# Altium schematic sheet 03_Catalina.SchDoc
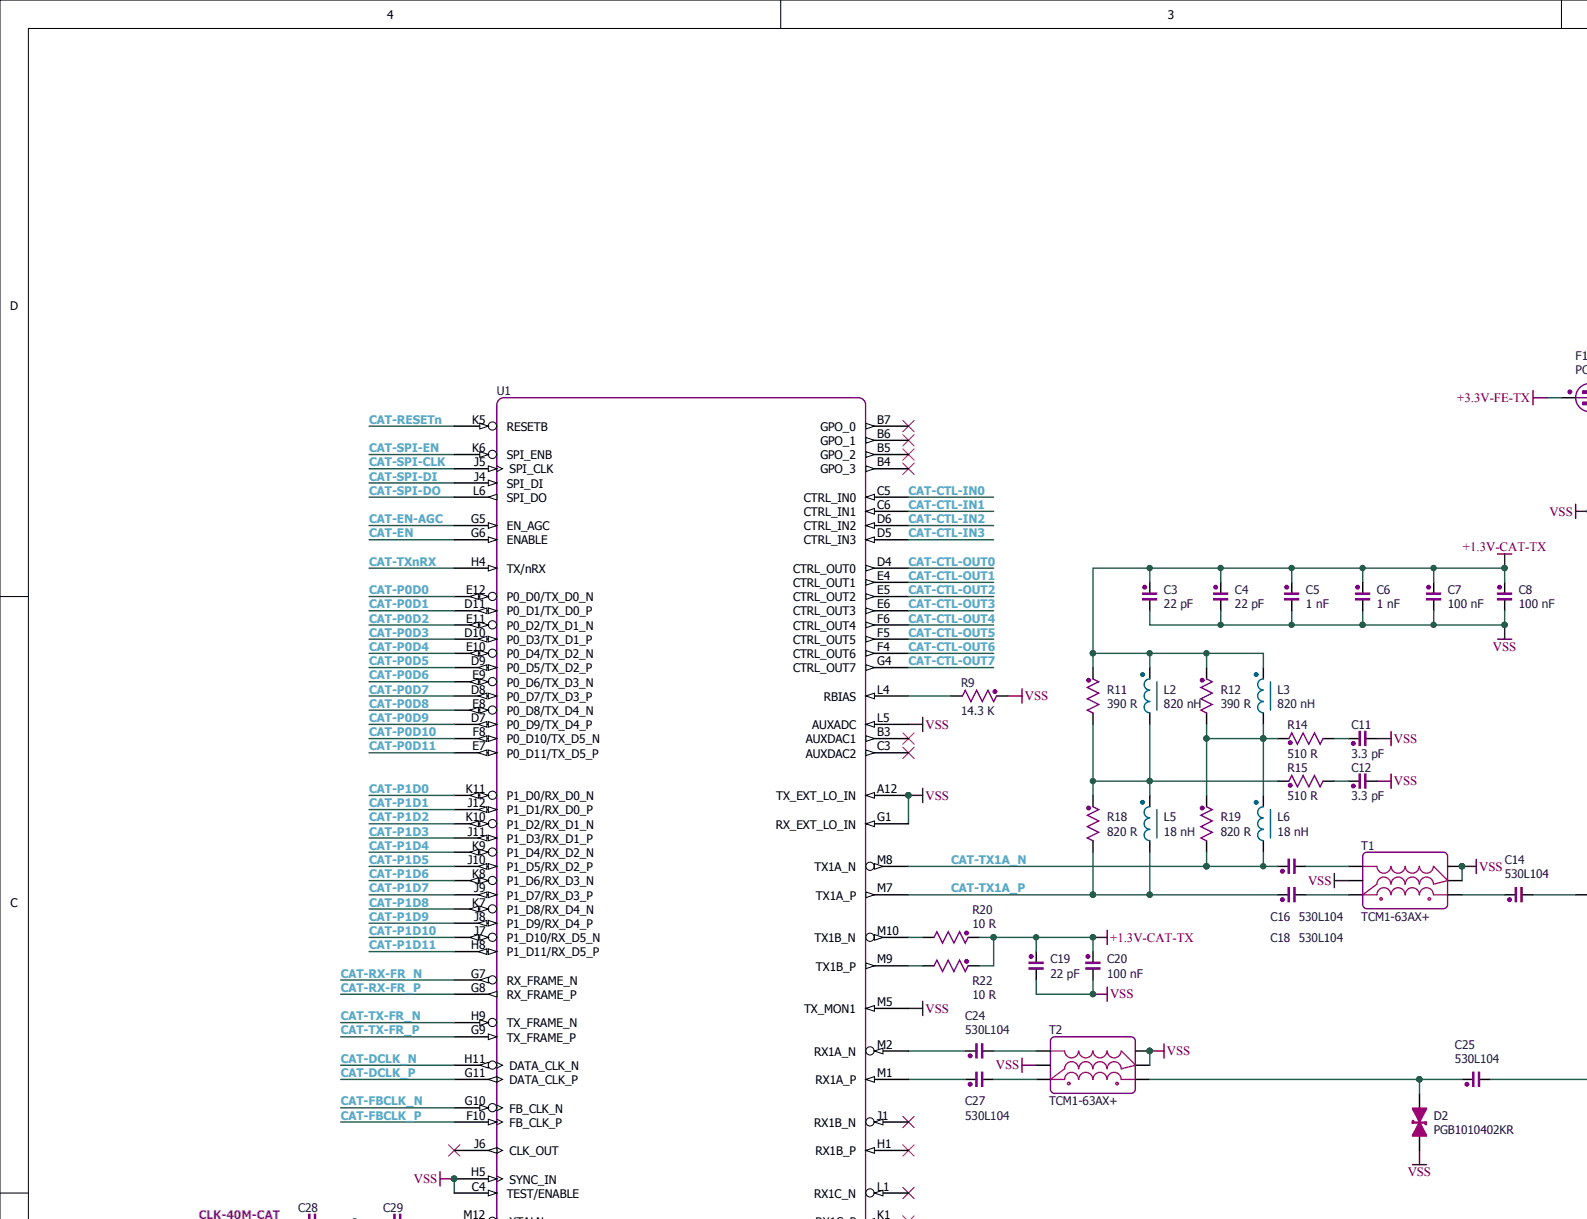
[diagram p0: 03_Catalina.SchDoc, top left]
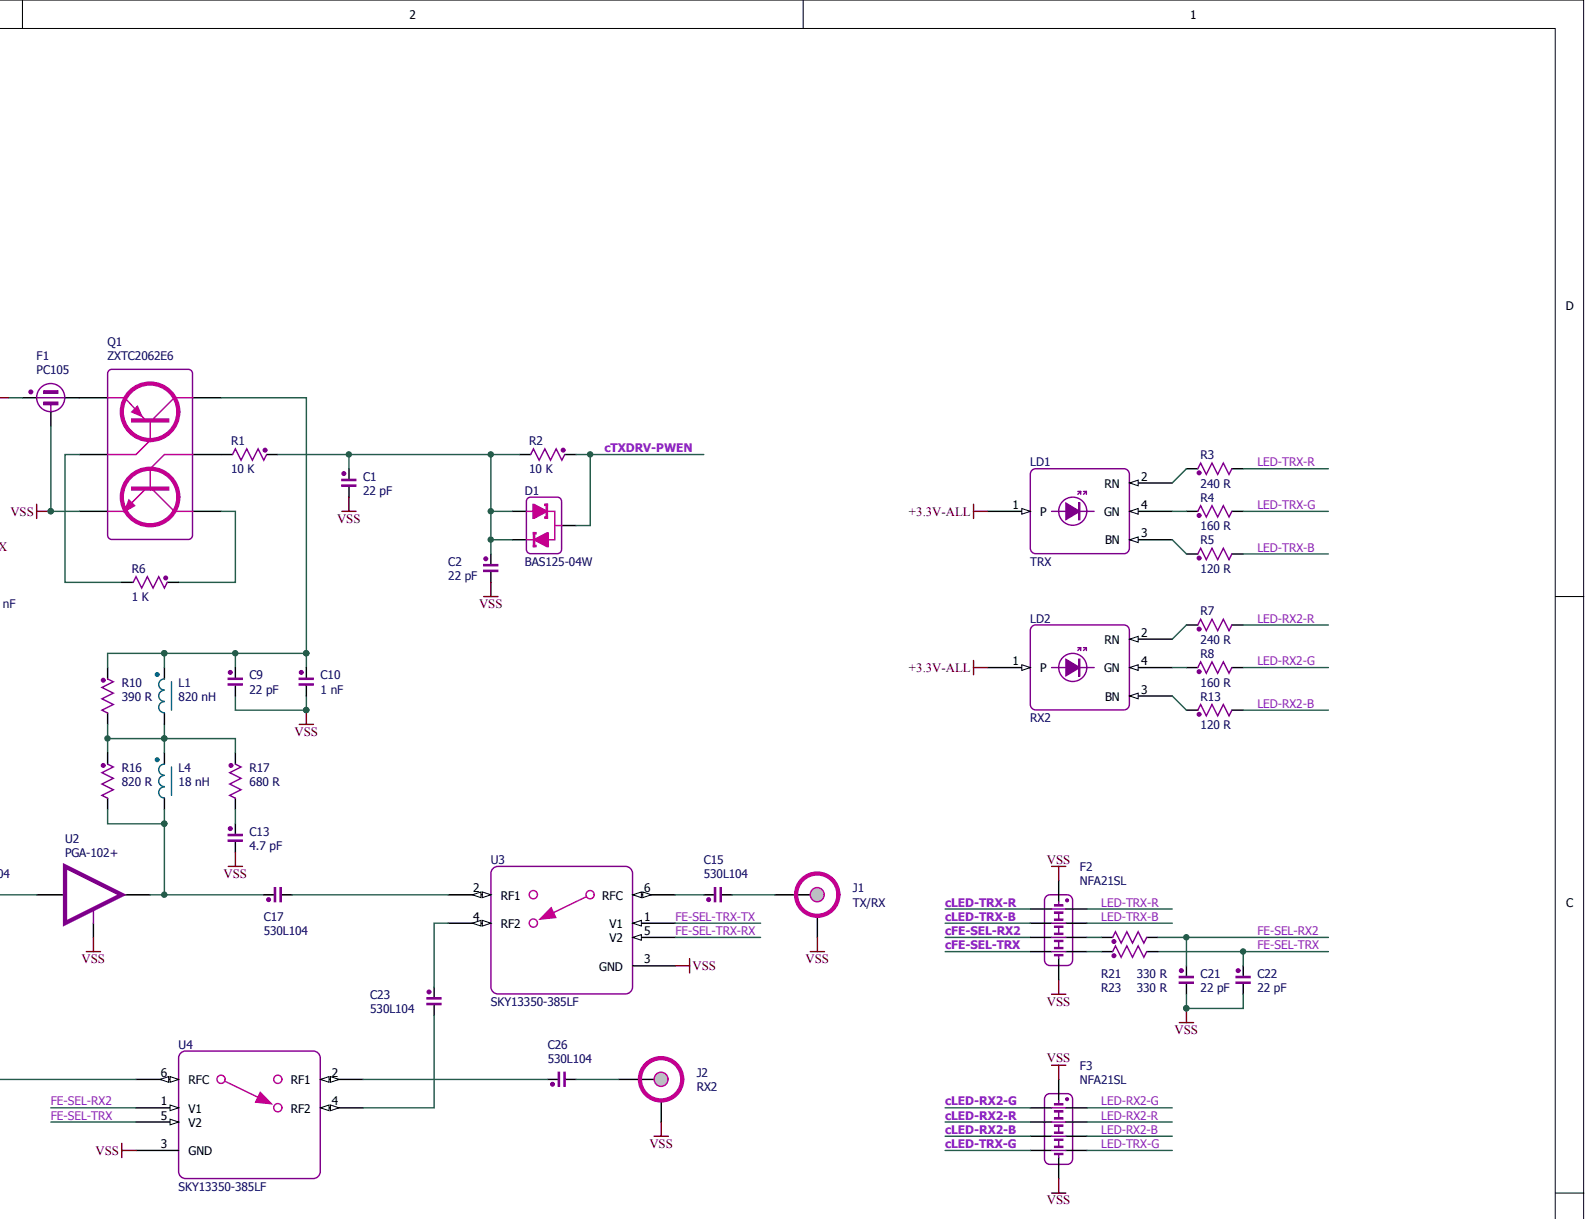
[diagram p1: 03_Catalina.SchDoc, top right]
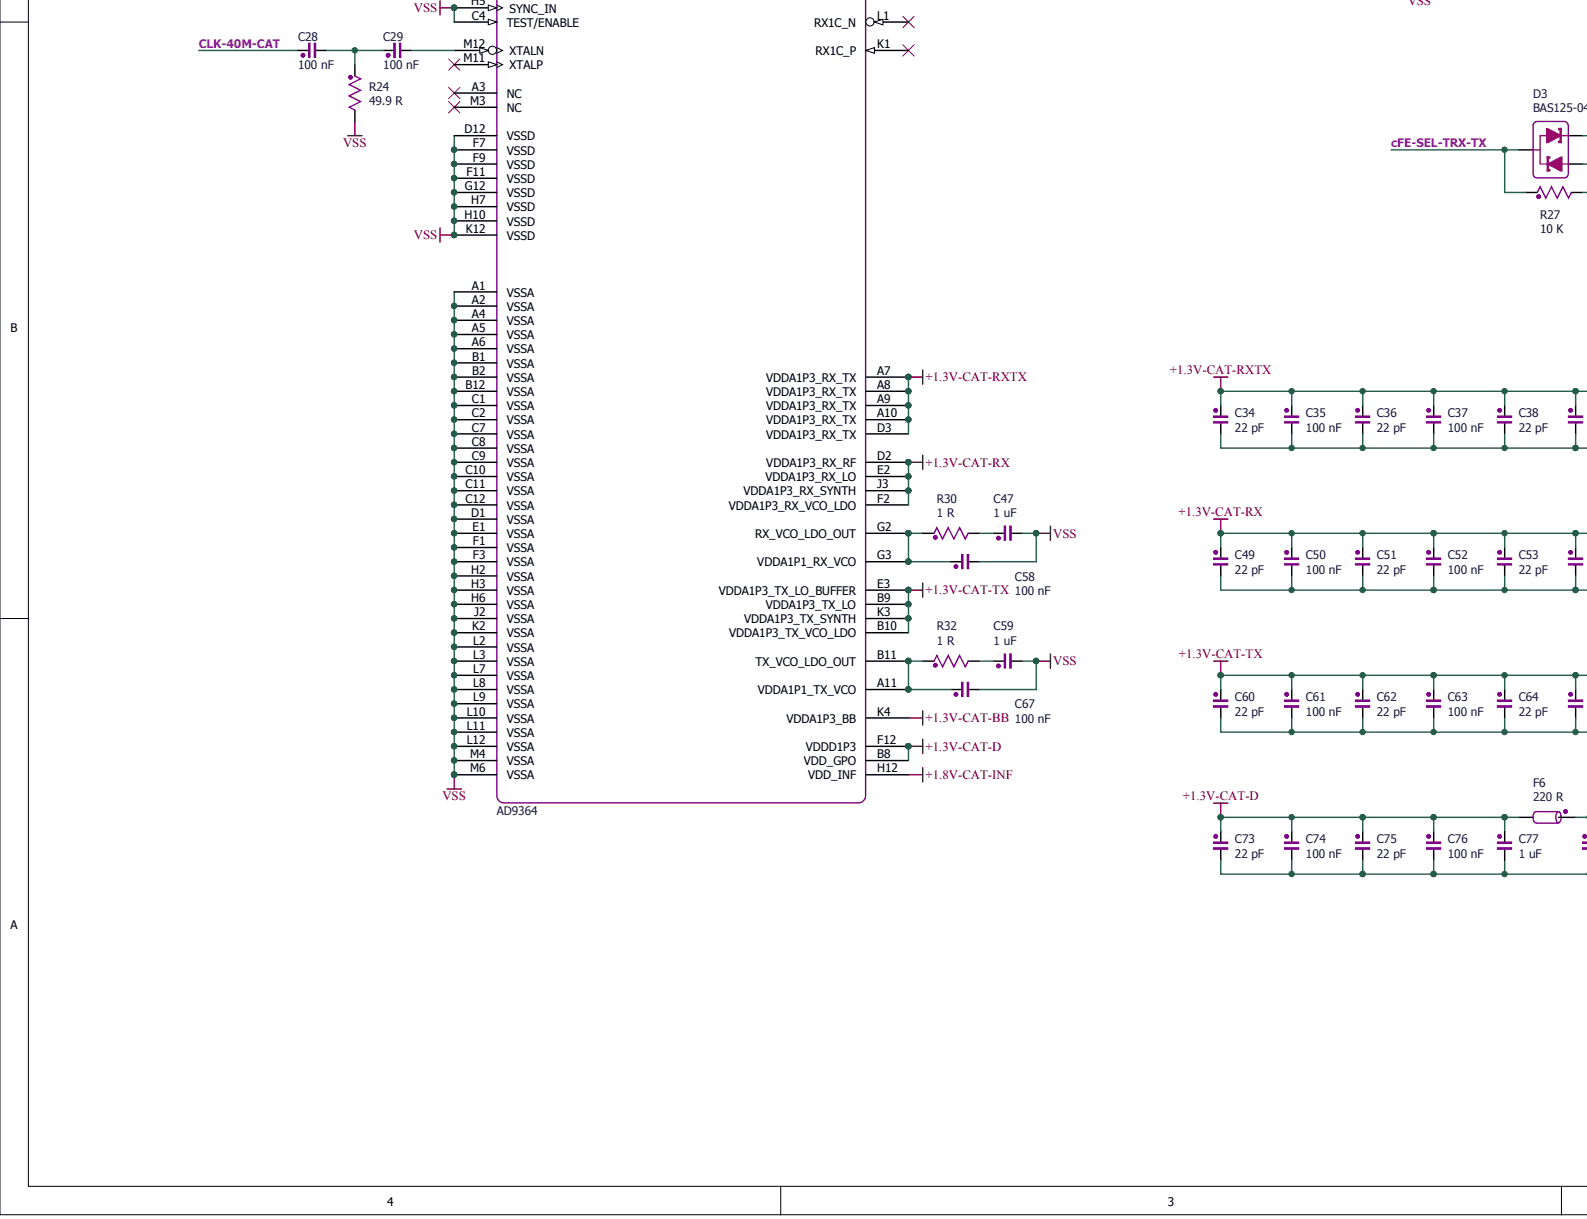
[diagram p2: 03_Catalina.SchDoc, bottom left]
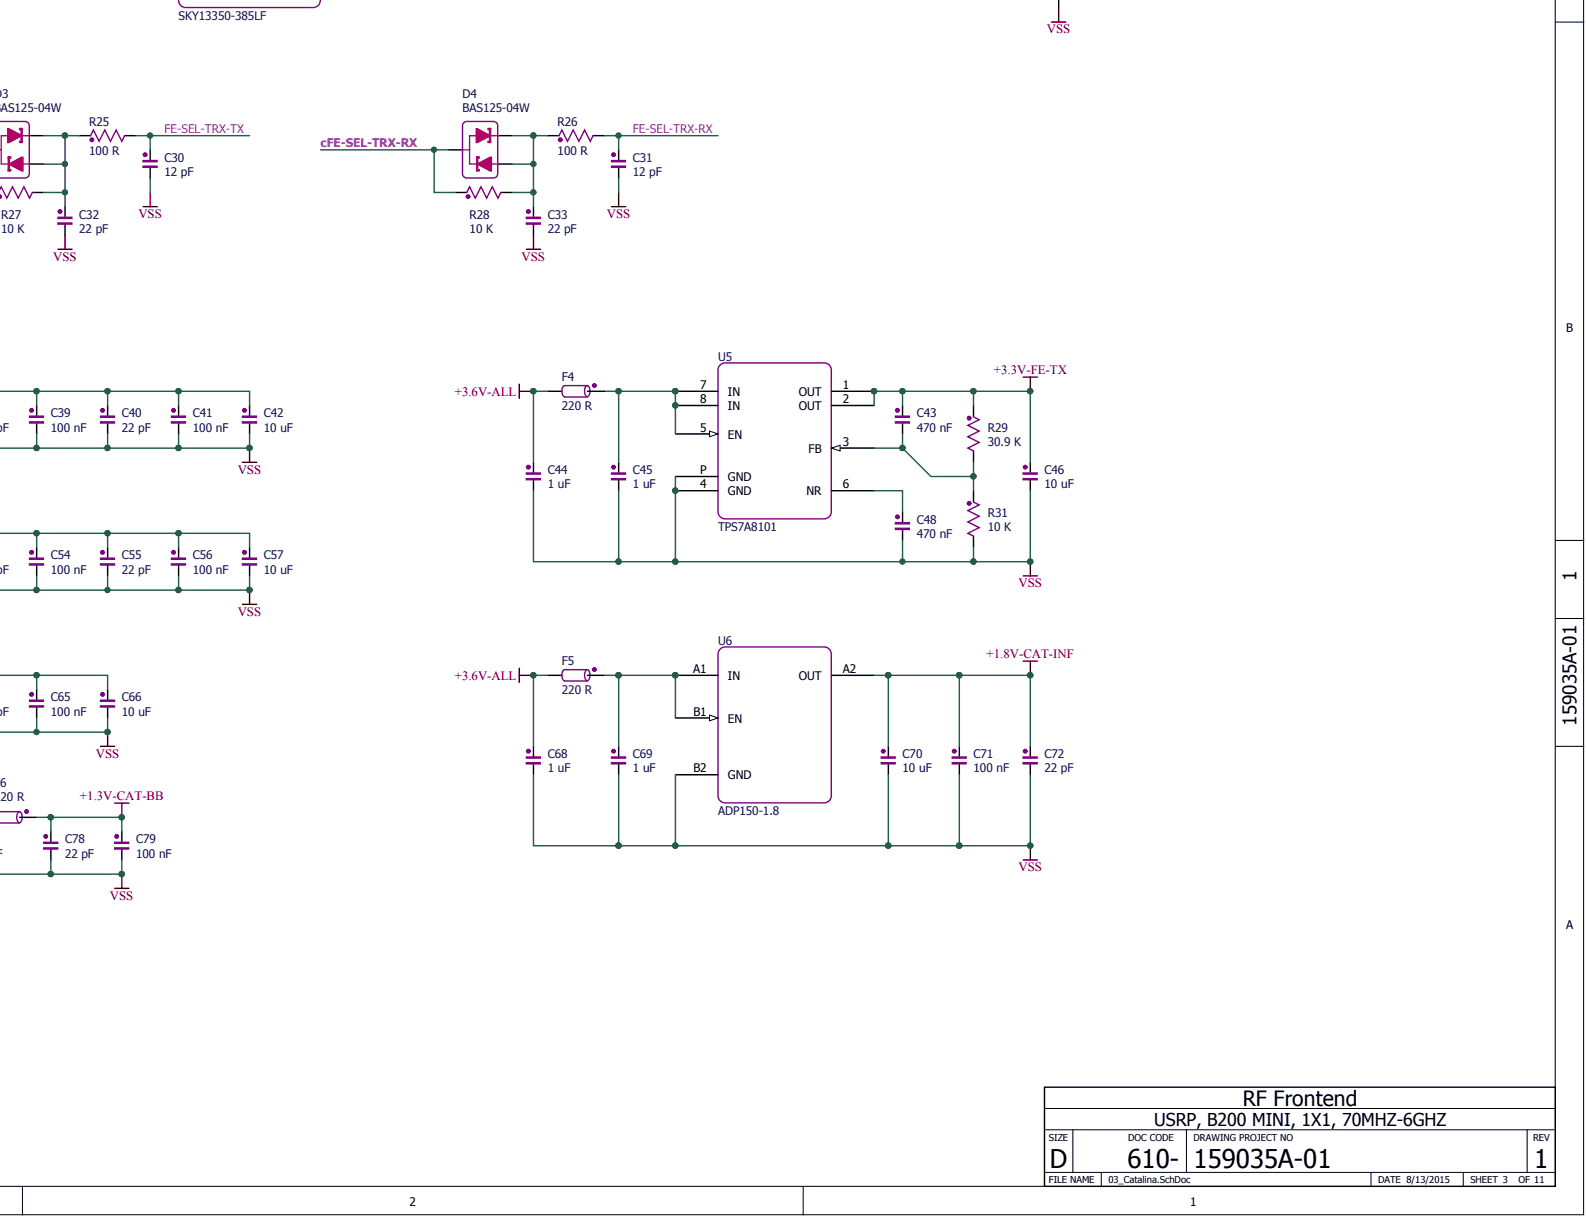
[diagram p3: 03_Catalina.SchDoc, bottom right]

## Parts
C1  22 pF  [0402-CAP-RF]
C2  22 pF  [0402-CAP-RF]
C3  22 pF  [0201-CAP-RF]
C4  22 pF  [0201-CAP-RF]
C5  1 nF  [0201-CAP]
C6  1 nF  [0201-CAP]
C7  100 nF  [0201-CAP]
C8  100 nF  [0201-CAP]
C9  22 pF  [0402-CAP-rf]
C10  1 nF  [0402-CAP]
C11  3.3 pF  [0201-CAP-RF]
C12  3.3 pF  [0201-CAP-RF]
C13  4.7 pF  [0201-CAP-RF]
C14  530L104  [0402-CAP-RF]
C15  530L104  [0402-CAP-RF]
C16  530L104  [0402-CAP-RF]
C17  530L104  [0402-CAP-RF]
C18  530L104  [0402-CAP-RF]
C19  22 pF  [0201-CAP-RF]
C20  100 nF  [0201-CAP]
C21  22 pF  [0201-CAP-RF]
C22  22 pF  [0201-CAP-RF]
C23  530L104  [0402-CAP-rf]
C24  530L104  [0402-CAP-RF]
C25  530L104  [0402-CAP-rf]
C26  530L104  [0402-CAP-RF]
C27  530L104  [0402-CAP-RF]
C28  100 nF  [0201-CAP]
C29  100 nF  [0201-CAP]
C30  12 pF  [0201-CAP-RF]
C31  12 pF  [0201-CAP-RF]
C32  22 pF  [0402-CAP-RF]
C33  22 pF  [0402-CAP-RF]
C34  22 pF  [0201-CAP-RF]
C35  100 nF  [0201-CAP]
C36  22 pF  [0201-CAP-RF]
C37  100 nF  [0201-CAP]
C38  22 pF  [0201-CAP-RF]
C39  100 nF  [0201-CAP]
C40  22 pF  [0201-CAP-RF]
C41  100 nF  [0201-CAP]
C42  10 uF  [0402-CAP]
C43  470 nF  [0201-CAP]
C44  1 uF  [0402-CAP]
C45  1 uF  [0402-CAP]
C46  10 uF  [0402-CAP]
C47  1 uF  [0402-CAP]
C48  470 nF  [0201-CAP]
C49  22 pF  [0201-CAP-RF]
C50  100 nF  [0201-CAP]
C51  22 pF  [0201-CAP-RF]
C52  100 nF  [0201-CAP]
C53  22 pF  [0201-CAP-RF]
C54  100 nF  [0201-CAP]
C55  22 pF  [0201-CAP-RF]
C56  100 nF  [0201-CAP]
C57  10 uF  [0402-CAP]
C58  100 nF  [0201-CAP]
C59  1 uF  [0402-CAP]
C60  22 pF  [0201-CAP-RF]
C61  100 nF  [0201-CAP]
C62  22 pF  [0201-CAP-RF]
C63  100 nF  [0201-CAP]
C64  22 pF  [0201-CAP-RF]
C65  100 nF  [0201-CAP]
C66  10 uF  [0402-CAP]
C67  100 nF  [0201-CAP]
C68  1 uF  [0402-CAP]
C69  1 uF  [0402-CAP]
C70  10 uF  [0402-CAP]
C71  100 nF  [0201-CAP]
C72  22 pF  [0201-CAP-RF]
C73  22 pF  [0201-CAP-RF]
C74  100 nF  [0201-CAP]
C75  22 pF  [0201-CAP-RF]
C76  100 nF  [0201-CAP]
C77  1 uF  [0402-CAP]
C78  22 pF  [0201-CAP-RF]
C79  100 nF  [0201-CAP]
D1  BAS125-04W  [SOT-323]
D2  PGB1010402KR  [0402-TVS]
D3  BAS125-04W  [SOT-323]
D4  BAS125-04W  [SOT-323]
F1  PC105  [0805-NF]
F2  NFA21SL  [0402-NF-4]
F3  NFA21SL  [0402-NF-4]
F4  220 R  [0402-BEAD]
F5  220 R  [0402-BEAD]
F6  220 R  [0402-BEAD]
J1  TX/RX  [142-0771-821]
J2  RX2  [142-0771-821]
L1  820 nH  [0603LS]
L2  820 nH  [0603LS]
L3  820 nH  [0603LS]
L4  18 nH  [0403HQ]
L5  18 nH  [0403HQ]
L6  18 nH  [0403HQ]
LD1  TRX  [SMLP34]
LD2  RX2  [SMLP34]
Q1  ZXTC2062E6  [SOT-26]
R1  10 K  [0402-RES]
R2  10 K  [0402-RES]
R3  240 R  [0201-RES]
R4  160 R  [0201-RES]
R5  120 R  [0201-RES]
R6  1 K  [0402-RES]
R7  240 R  [0201-RES]
R8  160 R  [0201-RES]
R9  14.3 K  [0402-RES-H]
R10  390 R  [0402-RES-H]
R11  390 R  [0402-RES-H]
R12  390 R  [0402-RES-H]
R13  120 R  [0201-RES]
R14  510 R  [0201-RES]
R15  510 R  [0201-RES]
R16  820 R  [0201-RES]
R17  680 R  [0201-RES]
R18  820 R  [0201-RES]
R19  820 R  [0201-RES]
R20  10 R  [0201-RES]
R21  330 R  [0201-RES]
R22  10 R  [0201-RES]
R23  330 R  [0201-RES]
R24  49.9 R  [0201-RES]
R25  100 R  [0201-RES]
R26  100 R  [0201-RES]
R27  10 K  [0402-RES]
R28  10 K  [0402-RES]
R29  30.9 K  [0201-RES]
R30  1 R  [0201-RES]
R31  10 K  [0201-RES]
R32  1 R  [0201-RES]
T1  TCM1-63AX+  [DB1627]
T2  TCM1-63AX+  [DB1627]
U1  AD9364  [BC-144-7-AD9364]
U2  PGA-102+  [SOT-89]
U3  SKY13350-385LF  [MLPD-6]
U4  SKY13350-385LF  [MLPD-6]
U5  TPS7A8101  [TI-SON-8]
U6  ADP150-1.8  [ADI-WLCSP-4]

## Nets
+1.3V-CAT-BB: C78.2 C79.2 F6.2 U1.K4(VDDA1P3_BB)
+1.3V-CAT-D: C73.2 C74.2 C75.2 C76.2 C77.2 F6.1 U1.B8(VDD_GPO) U1.F12(VDDD1P3)
+1.3V-CAT-RX: C49.2 C50.2 C51.2 C52.2 C53.2 C54.2 C55.2 C56.2 C57.2 U1.D2(VDDA1P3_RX_RF) U1.E2(VDDA1P3_RX_LO) U1.F2(VDDA1P3_RX_VCO_LDO) U1.J3(VDDA1P3_RX_SYNTH)
+1.3V-CAT-RXTX: C34.2 C35.2 C36.2 C37.2 C38.2 C39.2 C40.2 C41.2 C42.2 U1.A7(VDDA1P3_RX_TX) U1.A8(VDDA1P3_RX_TX) U1.A9(VDDA1P3_RX_TX) U1.A10(VDDA1P3_RX_TX) U1.D3(VDDA1P3_RX_TX)
+1.3V-CAT-TX: C3.2 C4.2 C5.2 C6.2 C7.2 C8.2 C19.2 C20.2 C60.2 C61.2 C62.2 C63.2 C64.2 C65.2 C66.2 L2.2 L3.2 R11.2 R12.2 R20.2 R22.2 U1.B9(VDDA1P3_TX_LO) U1.B10(VDDA1P3_TX_VCO_LDO) U1.E3(VDDA1P3_TX_LO_BUFFER) U1.K3(VDDA1P3_TX_SYNTH)
+1.8V-CAT-INF: C70.2 C71.2 C72.2 U1.H12(VDD_INF) U6.A2(OUT)
+3.3V-ALL: LD1.1(P) LD2.1(P)
+3.3V-FE-TX: C43.2 C46.2 F1.2 R29.2 U5.1(OUT) U5.2(OUT)
+3.6V-ALL: C44.2 C68.2 F4.1 F5.1
VSS: C1.1 C2.1 C3.1 C4.1 C5.1 C6.1 C7.1 C8.1 C9.1 C10.1 C11.1 C12.1 C13.1 C19.1 C20.1 C21.1 C22.1 C30.1 C31.1 C32.1 C33.1 C34.1 C35.1 C36.1 C37.1 C38.1 C39.1 C40.1 C41.1 C42.1 C44.1 C45.1 C46.1 C47.1 C48.1 C49.1 C50.1 C51.1 C52.1 C53.1 C54.1 C55.1 C56.1 C57.1 C58.1 C59.1 C60.1 C61.1 C62.1 C63.1 C64.1 C65.1 C66.1 C67.1 C68.1 C69.1 C70.1 C71.1 C72.1 C73.1 C74.1 C75.1 C76.1 C77.1 C78.1 C79.1 D2.2 F1.3 F2.9 F2.10 F3.9 F3.10 J1.2 J2.2 Q1.6 R9.2 R24.1 R31.1 T1.2 T1.3 T1.5 T2.2 T2.3 T2.5 U1.A1(VSSA) U1.A2(VSSA) U1.A4(VSSA) U1.A5(VSSA) U1.A6(VSSA) U1.A12(TX_EXT_LO_IN) U1.B1(VSSA) U1.B2(VSSA) U1.B12(VSSA) U1.C1(VSSA) U1.C2(VSSA) U1.C4(TEST/ENABLE) U1.C7(VSSA) U1.C8(VSSA) U1.C9(VSSA) U1.C10(VSSA) U1.C11(VSSA) U1.C12(VSSA) U1.D1(VSSA) U1.D12(VSSD) U1.E1(VSSA) U1.F1(VSSA) U1.F3(VSSA) U1.F7(VSSD) U1.F9(VSSD) U1.F11(VSSD) U1.G1(RX_EXT_LO_IN) U1.G12(VSSD) U1.H2(VSSA) U1.H3(VSSA) U1.H5(SYNC_IN) U1.H6(VSSA) U1.H7(VSSD) U1.H10(VSSD) U1.J2(VSSA) U1.K2(VSSA) U1.K12(VSSD) U1.L2(VSSA) U1.L3(VSSA) U1.L5(AUXADC) U1.L7(VSSA) U1.L8(VSSA) U1.L9(VSSA) U1.L10(VSSA) U1.L11(VSSA) U1.L12(VSSA) U1.M4(VSSA) U1.M5(TX_MON1) U1.M6(VSSA) U2.2 U3.3(GND) U4.3(GND) U5.4(GND) U5.P(GND) U6.B2(GND)
CAT-CTL-IN0: U1.C5(CTRL_IN0)
CAT-CTL-IN1: U1.C6(CTRL_IN1)
CAT-CTL-IN2: U1.D6(CTRL_IN2)
CAT-CTL-IN3: U1.D5(CTRL_IN3)
CAT-CTL-OUT0: U1.D4(CTRL_OUT0)
CAT-CTL-OUT1: U1.E4(CTRL_OUT1)
CAT-CTL-OUT2: U1.E5(CTRL_OUT2)
CAT-CTL-OUT3: U1.E6(CTRL_OUT3)
CAT-CTL-OUT4: U1.F6(CTRL_OUT4)
CAT-CTL-OUT5: U1.F5(CTRL_OUT5)
CAT-CTL-OUT6: U1.F4(CTRL_OUT6)
CAT-CTL-OUT7: U1.G4(CTRL_OUT7)
CAT-DCLK_N: U1.H11(DATA_CLK_N)
CAT-DCLK_P: U1.G11(DATA_CLK_P)
CAT-EN: U1.G6(ENABLE)
CAT-EN-AGC: U1.G5(EN_AGC)
CAT-FBCLK_N: U1.G10(FB_CLK_N)
CAT-FBCLK_P: U1.F10(FB_CLK_P)
CAT-P0D0: U1.E12(P0_D0/TX_D0_N)
CAT-P0D1: U1.D11(P0_D1/TX_D0_P)
CAT-P0D2: U1.E11(P0_D2/TX_D1_N)
CAT-P0D3: U1.D10(P0_D3/TX_D1_P)
CAT-P0D4: U1.E10(P0_D4/TX_D2_N)
CAT-P0D5: U1.D9(P0_D5/TX_D2_P)
CAT-P0D6: U1.E9(P0_D6/TX_D3_N)
CAT-P0D7: U1.D8(P0_D7/TX_D3_P)
CAT-P0D8: U1.E8(P0_D8/TX_D4_N)
CAT-P0D9: U1.D7(P0_D9/TX_D4_P)
CAT-P0D10: U1.F8(P0_D10/TX_D5_N)
CAT-P0D11: U1.E7(P0_D11/TX_D5_P)
CAT-P1D0: U1.K11(P1_D0/RX_D0_N)
CAT-P1D1: U1.J12(P1_D1/RX_D0_P)
CAT-P1D2: U1.K10(P1_D2/RX_D1_N)
CAT-P1D3: U1.J11(P1_D3/RX_D1_P)
CAT-P1D4: U1.K9(P1_D4/RX_D2_N)
CAT-P1D5: U1.J10(P1_D5/RX_D2_P)
CAT-P1D6: U1.K8(P1_D6/RX_D3_N)
CAT-P1D7: U1.J9(P1_D7/RX_D3_P)
CAT-P1D8: U1.K7(P1_D8/RX_D4_N)
CAT-P1D9: U1.J8(P1_D9/RX_D4_P)
CAT-P1D10: U1.J7(P1_D10/RX_D5_N)
CAT-P1D11: U1.H8(P1_D11/RX_D5_P)
CAT-RESETN: U1.K5(RESETB)
CAT-RX-FR_N: U1.G7(RX_FRAME_N)
CAT-RX-FR_P: U1.G8(RX_FRAME_P)
CAT-SPI-CLK: U1.J5(SPI_CLK)
CAT-SPI-DI: U1.J4(SPI_DI)
CAT-SPI-DO: U1.L6(SPI_DO)
CAT-SPI-EN: U1.K6(SPI_ENB)
CAT-TX1A_N: C16.2 L6.1 R19.1 U1.M8(TX1A_N)
CAT-TX1A_P: C18.2 L5.1 R18.1 U1.M7(TX1A_P)
CAT-TX-FR_N: U1.H9(TX_FRAME_N)
CAT-TX-FR_P: U1.G9(TX_FRAME_P)
CAT-TXNRX: U1.H4(TX/nRX)
CFE-SEL-RX2: F2.6
CFE-SEL-TRX: F2.8
CFE-SEL-TRX-RX: D4.2 R28.2
CFE-SEL-TRX-TX: D3.2 R27.2
CLED-RX2-B: F3.6
CLED-RX2-G: F3.2
CLED-RX2-R: F3.4
CLED-TRX-B: F2.4
CLED-TRX-G: F3.8
CLED-TRX-R: F2.2
CLK-40M-CAT: C28.2
CTXDRV-PWEN: D1.2 R2.2
FE-SEL-RX2: C21.2 R21.1 U4.1(V1)
FE-SEL-TRX: C22.2 R23.1 U4.5(V2)
FE-SEL-TRX-RX: C31.2 R26.1 U3.5(V2)
FE-SEL-TRX-TX: C30.2 R25.1 U3.1(V1)
LED-RX2-B: F3.5 R13.1
LED-RX2-G: F3.1 R8.1
LED-RX2-R: F3.3 R7.1
LED-TRX-B: F2.3 R5.1
LED-TRX-G: F3.7 R4.1
LED-TRX-R: F2.1 R3.1
NetC1_2: C1.2 C2.2 D1.1 D1.3 R1.2 R2.1
NetC9_2: C9.2 C10.2 L1.2 Q1.3 R10.2
NetC11_2: C11.2 R14.1
NetC12_2: C12.2 R15.1
NetC13_2: C13.2 R17.1
NetC14_1: C14.1 U2.1
NetC14_2: C14.2 T1.1
NetC15_1: C15.1 J1.1
NetC15_2: C15.2 U3.6(RFC)
NetC16_1: C16.1 T1.4
NetC17_1: C17.1 U3.2(RF1)
NetC17_2: C17.2 L4.1 R16.1 U2.3
NetC18_1: C18.1 T1.6
NetC23_1: C23.1 U4.4(RF2)
NetC23_2: C23.2 U3.4(RF2)
NetC24_1: C24.1 T2.4
NetC24_2: C24.2 U1.M2(RX1A_N)
NetC25_1: C25.1 U4.6(RFC)
NetC25_2: C25.2 D2.1 T2.1
NetC26_1: C26.1 J2.1
NetC26_2: C26.2 U4.2(RF1)
NetC27_1: C27.1 T2.6
NetC27_2: C27.2 U1.M1(RX1A_P)
NetC28_1: C28.1 C29.2 R24.2
NetC29_1: C29.1 U1.M12(XTALN)
NetC32_2: C32.2 D3.1 D3.3 R25.2 R27.1
NetC33_2: C33.2 D4.1 D4.3 R26.2 R28.1
NetC43_1: C43.1 R29.1 R31.2 U5.3(FB)
NetC45_2: C45.2 F4.2 U5.5(EN) U5.7(IN) U5.8(IN)
NetC47_2: C47.2 R30.1
NetC48_2: C48.2 U5.6(NR)
NetC58_2: C58.2 R30.2 U1.G2(RX_VCO_LDO_OUT) U1.G3(VDDA1P1_RX_VCO)
NetC59_2: C59.2 R32.1
NetC67_2: C67.2 R32.2 U1.A11(VDDA1P1_TX_VCO) U1.B11(TX_VCO_LDO_OUT)
NetC69_2: C69.2 F5.2 U6.A1(IN) U6.B1(EN)
NetF1_1: F1.1 Q1.4
NetF2_5: F2.5 R21.2
NetF2_7: F2.7 R23.2
NetL1_1: L1.1 L4.2 R10.1 R16.2 R17.2
NetL2_1: L2.1 L5.2 R11.1 R15.2 R18.2
NetL3_1: L3.1 L6.2 R12.1 R14.2 R19.2
NetLD1_2: LD1.2(RN) R3.2
NetLD1_3: LD1.3(BN) R5.2
NetLD1_4: LD1.4(GN) R4.2
NetLD2_2: LD2.2(RN) R7.2
NetLD2_3: LD2.3(BN) R13.2
NetLD2_4: LD2.4(GN) R8.2
NetQ1_1: Q1.1 R6.2
NetQ1_2: Q1.2 R1.1
NetQ1_5: Q1.5 R6.1
NetR9_1: R9.1 U1.L4(RBIAS)
NetR20_1: R20.1 U1.M10(TX1B_N)
NetR22_1: R22.1 U1.M9(TX1B_P)

Not connected: U1 A3(NC) B3(AUXDAC1) B4(GPO_3) B5(GPO_2) B6(GPO_1) B7(GPO_0) C3(AUXDAC2) H1(RX1B_P) J1(RX1B_N) J6(CLK_OUT) K1(RX1C_P) L1(RX1C_N) M3(NC) M11(XTALP)

## Library data of the parts
C1 C2 C9 C32 C33 = CAP: CAP, SM, 22PF, 50V, 2%, COG, CER, 0402, H=.025IN; PartNumber: C0402C0G500-220GNP; Designer: shyul; PCBMarker: Capacitor; PinCountHole: 0; PinCountSMD: 2; Vendor: VENKEL; Component Kind: Standard; SerialNumber: 0; Value: 22 pF; Version: 1.0; VersionDate: 04-27-2011; Library Name: Basc_1_Passive.IntLib; Publisher: Cowin
C3 C4 C19 C21 C22 C34 C36 C38 C40 C49 C51 C53 C55 C60 C62 C64 C72 C73 C75 C78 = CAP: CAP, SM, 22PF, 25V, 5%, CER, C0G, 0201, H=.010IN; PartNumber: C0201C0G250-220JNP; Designer: shyul; PCBMarker: Capacitor; PinCountHole: 0; PinCountSMD: 2; SerialNumber: 716728-01; Vendor: VENKEL; Component Kind: Standard; Value: 22 pF; Version: 1.0; VersionDate: 04-27-2011; Library Name: Basc_1_Passive.IntLib; Publisher: Cowin
C5 C6 = CAP: CAP, 1nF, X7R, 10V, ±10%, 0201, PbF; PartNumber: C0201X7R100-102KNP; Designer: shyul; PCBMarker: Capacitor; PinCountHole: 0; PinCountSMD: 2; Vendor: VENKEL; Component Kind: Standard; SerialNumber: 0; Value: 1 nF; Version: 1.0; VersionDate: 04-27-2011; Library Name: Basc_1_Passive.IntLib; Publisher: Cowin
C7 C8 C20 C28 C29 C35 C37 C39 C41 C50 C52 C54 C56 C58 C61 C63 C65 C67 C71 C74 C76 C79 = CAP: CAP,SM,0.1UF,16V,10%,CER,X5R,0201,H=.014IN; PartNumber: GRM033R61C104KE14D; Designer: shyul; PCBMarker: Capacitor; PinCountHole: 0; PinCountSMD: 2; SerialNumber: 717062-01; Vendor: MURATA; Component Kind: Standard; Value: 100 nF; Version: 1.0; VersionDate: 04-27-2011; Library Name: Basc_1_Passive.IntLib; Publisher: Cowin
C10 = CAP: CAP, 1nF, C0G, 25V, ±5%, 0402, PbF; PartNumber: C0402C0G500-102JNP; Designer: shyul; PCBMarker: Capacitor; PinCountHole: 0; PinCountSMD: 2; Vendor: VENKEL; Component Kind: Standard; SerialNumber: 0; Value: 1 nF; Version: 1.0; VersionDate: 04-27-2011; Library Name: Basc_1_Passive.IntLib; Publisher: Cowin
C11 C12 = CAP: CAP,SM,3.3PF,+/-.1PF,50V,C0G,0201,H=.01IN; PartNumber: GRM0335C1H3R3BA01D; Designer: shyul; PCBMarker: Capacitor; PinCountHole: 0; PinCountSMD: 2; SerialNumber: 717100-01; Vendor: muRata; Component Kind: Standard; Value: 3.3 pF; Version: 1.0; VersionDate: 04-27-2011; Library Name: Basc_1_Passive.IntLib; Publisher: Cowin
C13 = CAP: CAP,SM,4.7PF,+/-.1PF,50V,C0G,0201,H=.013IN; PartNumber: GRM0335C1H4R7BA01D; Designer: shyul; PCBMarker: Capacitor; PinCountHole: 0; PinCountSMD: 2; SerialNumber: 717101-01; Vendor: muRata; Component Kind: Standard; Value: 4.7 pF; Version: 1.0; VersionDate: 04-27-2011; Library Name: Basc_1_Passive.IntLib; Publisher: Cowin
C14-C18 C23-C27 = CAP: CAP, 0.1uF, Hi-Q, X7R, 16V, ±10%, 0402, PbF; PartNumber: ATC530L104KT16T; Designer: shyul; PCBMarker: Capacitor; PinCountHole: 0; PinCountSMD: 2; Vendor: ATC; Component Kind: Standard; SerialNumber: 0; Value: 530L104; Version: 1.0; VersionDate: 04-27-2011; Library Name: Basc_1_Passive.IntLib; Publisher: Cowin
C30 C31 = CAP: CAP, 12pF, C0G, 25V, ±5%, 0201, PbF; PartNumber: C0201C0G250-120JNP; Designer: shyul; PCBMarker: Capacitor; PinCountHole: 0; PinCountSMD: 2; Vendor: VENKEL; Component Kind: Standard; SerialNumber: 0; Value: 12 pF; Version: 1.0; VersionDate: 04-27-2011; Library Name: Basc_1_Passive.IntLib; Publisher: Cowin
C42 C46 C57 C66 C70 = CAP: CAP, 10uF, X5R, 6.3V, ±20%, 0402, PbF; PartNumber: C0402X5R6R3-106MNP; Designer: shyul; PCBMarker: Capacitor; PinCountHole: 0; PinCountSMD: 2; Vendor: VENKEL; Component Kind: Standard; SerialNumber: 0; Value: 10 uF; Version: 1.0; VersionDate: 04-27-2011; Library Name: Basc_1_Passive.IntLib; Publisher: Cowin
C43 C48 = CAP: CAP, 470nF, X5R, 6.3V, ±10%, 0201, PbF; PartNumber: C0201X5R6R3-474KNP; Designer: shyul; PCBMarker: Capacitor; PinCountHole: 0; PinCountSMD: 2; Vendor: VENKEL; Component Kind: Standard; SerialNumber: 0; Value: 470 nF; Version: 1.0; VersionDate: 04-27-2011; Library Name: Basc_1_Passive.IntLib; Publisher: Cowin
C44 C45 C47 C59 C68 C69 C77 = CAP: CAP, 1uF, X5R, 10V, ±10%, 0402, PbF; PartNumber: C0402X5R100-105KNP; Designer: shyul; PCBMarker: Capacitor; PinCountHole: 0; PinCountSMD: 2; Vendor: VENKEL; Component Kind: Standard; SerialNumber: 0; Value: 1 uF; Version: 1.0; VersionDate: 04-27-2011; Library Name: Basc_1_Passive.IntLib; Publisher: Cowin
D1 D3 D4 = BAS125-04W: RF Schottky Diodes; Designer: shyul; PinCountHole: 0; PinCountSMD: 3; SerialNumber: 730656-01; Vendor: Infineon; Component Kind: Standard; PartNumber: BAS125-04W; PCBMarker: Diodes; Value: BAS125-04W; Version: 1.0; VersionDate: 05-23-2014; Library Name: Basc_3_Diode_Transistor.IntLib; Publisher: Cowin
D2 = PGB10101402KR: ESD SUPPRESSOR, SM, 12VDC WORKING, 250V CLAMPING, 0402, H=.015IN; Designer: shyul; PCBMarker: Diodes; PinCountHole: 0; PinCountSMD: 3; SerialNumber: 730830-01; Vendor: Littelfuse; Component Kind: Standard; PartNumber: PGB1010402KR; Value: PGB1010402KR; Version: 1.0; VersionDate: 09-09-2014; Library Name: Basc_3_Diode_Transistor.IntLib; Publisher: Cowin
F1 = NFA: NFM, 1uF, 4A, 16V, 20%, 0805, PbF; PartNumber: NFM21PC105B1C3; Designer: shyul; PCBMarker: NFL; PinCountHole: 0; PinCountSMD: 4; Vendor: muRata; Component Kind: Standard; SerialNumber: 0; Value: PC105; Version: 1.0; VersionDate: 04-28-2011; Library Name: Basc_2_EMI_Protection.IntLib; Publisher: Cowin
F2 F3 = NFA_N4: EMI RC Filter Array x4; PartNumber: NFA21SL806X1A48L; Designer: shyul; PinCountHole: 0; PinCountSMD: 10; Vendor: muRata; Component Kind: Standard; PCBMarker: EMI; SerialNumber: 0; Value: NFA21SL; Version: 1.0; VersionDate: 04-27-2011; Library Name: Basc_2_EMI_Protection.IntLib; Publisher: Cowin
F4-F6 = BEAD: BEAD, 220R, 700mA, PbF; PartNumber: BLM15EG221SN1; Designer: shyul; PinCountHole: 0; PinCountSMD: 2; Vendor: muRata; Component Kind: Standard; PCBMarker: Bead; SerialNumber: 0; Value: 220 R; Version: 1.0; VersionDate: 04-28-2011; Library Name: Basc_2_EMI_Protection.IntLib; Publisher: Cowin
J1 J2 = SMA_JACK: CONN, SMA, JACK, END LAUNCH, PCB MOUNT, H=.134IN; Designer: shyul; PCBMarker: RF Connector; PinCountHole: 2; PinCountSMD: 3; SerialNumber: 768587-01; Vendor: Emerson; Component Kind: Standard; Library Name: Connector_3_Analog_RF.IntLib; PartNumber: 142-0771-821; Version: 1.0; VersionDate: 05-18-2011; Publisher: Cowin
  J1: Value: TX/RX
  J2: Value: RX2
L1-L3 = IND_RF: INDUCTOR,SM,820NH,2%,0.4A,0603LS,H=.044IN; PartNumber: 0603LS-821XGLC; Designer: shyul; PinCountHole: 0; PinCountSMD: 2; SerialNumber: 714888-01; Vendor: Coilcraft; Component Kind: Standard; Library Name: IC_06_RF.SchLib; PCBMarker: Inductor; Value: 820 nH; Version: 1.0; VersionDate: 05-02-2013; Publisher: Cowin
L4-L6 = IND_RF: IND, 18nH, ±5%, HiQ, 0402, PbF; PartNumber: 0403HQ-18NXJL; Designer: shyul; PCBMarker: Inductor; PinCountHole: 0; PinCountSMD: 2; Vendor: Coilcraft; Component Kind: Standard; Library Name: IC_06_RF.SchLib; SerialNumber: 0; Value: 18 nH; Version: 1.0; VersionDate: 05-02-2013; Publisher: Cowin
LD1 LD2 = SMLP34: PICOLED(TM)-RGB; PartNumber: SMLP34RGB2W; Designer: shyul; PCBMarker: LED; PinCountHole: 0; PinCountSMD: 4; Value: SMLP34; Vendor: Rohm; Component Kind: Standard; SerialNumber: 0; Version: 1.0; VersionDate: 12-04-2014; Library Name: Argon.SchLib; Publisher: Cowin
  LD1: Status: TRX
  LD2: Status: RX2
Q1 = ZXTC2062E6: 20V Complementary Medium Power Transistor; Designer: shyul; PCBMarker: NPN-PNP; PinCountHole: 0; PinCountSMD: 6; Vendor: ZETEX; Component Kind: Standard; PartNumber: ZXTC2062E6; SerialNumber: 0; Value: ZXTC2062E6; Version: 1.0; VersionDate: 05-23-2014; Library Name: Basc_3_Diode_Transistor.IntLib; Publisher: Cowin
R1 R2 R27 R28 = RES: RES, 10K, ±5%, 1/16W, 0402, PbF; PartNumber: CR0402-16W-103JT; Designer: shyul; PCBMarker: Resistor; PinCountHole: 0; PinCountSMD: 2; Vendor: VENKEL; Component Kind: Standard; SerialNumber: 0; Value: 10 K; Version: 1.0; VersionDate: 04-27-2011; Library Name: Basc_1_Passive.IntLib; Publisher: Cowin
R3 R7 = RES: RES, 240R, ±1%, 1/20W, 0201, PbF; PartNumber: RK73H1HTTC2400F; Designer: shyul; PCBMarker: Resistor; PinCountHole: 0; PinCountSMD: 2; Vendor: KOA; Component Kind: Standard; SerialNumber: 0; Value: 240 R; Version: 1.0; VersionDate: 04-27-2011; Library Name: Basc_1_Passive.IntLib; Publisher: Cowin
R4 R8 = RES: RES, 160R, ±1%, 1/20W, 0201, PbF; PartNumber: RK73H1HTTC1600F; Designer: shyul; PCBMarker: Resistor; PinCountHole: 0; PinCountSMD: 2; Vendor: KOA; Component Kind: Standard; SerialNumber: 0; Value: 160 R; Version: 1.0; VersionDate: 04-27-2011; Library Name: Basc_1_Passive.IntLib; Publisher: Cowin
R5 R13 = RES: RES, 120R, ±1%, 1/20W, 0201, PbF; PartNumber: RK73H1HTTC1200F; Designer: shyul; PCBMarker: Resistor; PinCountHole: 0; PinCountSMD: 2; Vendor: KOA; Component Kind: Standard; SerialNumber: 0; Value: 120 R; Version: 1.0; VersionDate: 04-27-2011; Library Name: Basc_1_Passive.IntLib; Publisher: Cowin
R6 = RES: RES, 1K, ±5%, 1/16W, 0402, PbF; PartNumber: RK73B1ETTP102J; Designer: shyul; PCBMarker: Resistor; PinCountHole: 0; PinCountSMD: 2; Vendor: KOA; Component Kind: Standard; SerialNumber: 0; Value: 1 K; Version: 1.0; VersionDate: 04-27-2011; Library Name: Basc_1_Passive.IntLib; Publisher: Cowin
R9 = RES: RES, 14.3K, ±1%, 1/16W, 0402, PbF; PartNumber: RK73H1ETTP1432F; Designer: shyul; PCBMarker: Resistor; PinCountHole: 0; PinCountSMD: 2; Vendor: KOA; Component Kind: Standard; SerialNumber: 0; Value: 14.3 K; Version: 1.0; VersionDate: 04-27-2011; Library Name: Basc_1_Passive.IntLib; Publisher: Cowin
R10-R12 = RES: RES, 390 R, ±1%, 1/16W, 0402, PbF; PartNumber: RK73H1ETTP3900F; Designer: shyul; PCBMarker: Resistor; PinCountHole: 0; PinCountSMD: 2; Vendor: KOA; Component Kind: Standard; SerialNumber: 0; Value: 390 R; Version: 1.0; VersionDate: 04-27-2011; Library Name: Basc_1_Passive.IntLib; Publisher: Cowin
R14 R15 = RES: RES,SM,510,1/20W,1%,THICK FILM,0201,H=.01IN; PartNumber: RK73H1HTTP5100F; Designer: shyul; PCBMarker: Resistor; PinCountHole: 0; PinCountSMD: 2; SerialNumber: 612244-01; Vendor: KOA; Component Kind: Standard; Value: 510 R; Version: 1.0; VersionDate: 04-27-2011; Library Name: Basc_1_Passive.IntLib; Publisher: Cowin
R16 R18 R19 = RES: RES, 820R, ±1%, 1/20W, 0201, PbF; PartNumber: RK73H1HTTC8200F; Designer: shyul; PCBMarker: Resistor; PinCountHole: 0; PinCountSMD: 2; Vendor: KOA; Component Kind: Standard; SerialNumber: 0; Value: 820 R; Version: 1.0; VersionDate: 04-27-2011; Library Name: Basc_1_Passive.IntLib; Publisher: Cowin
R17 = RES: RES, 680R, ±1%, 1/20W, 0201, PbF; PartNumber: RK73H1HTTP6800F; Designer: shyul; PCBMarker: Resistor; PinCountHole: 0; PinCountSMD: 2; Vendor: KOA; Component Kind: Standard; SerialNumber: 0; Value: 680 R; Version: 1.0; VersionDate: 04-27-2011; Library Name: Basc_1_Passive.IntLib; Publisher: Cowin
R20 R22 = RES: RES, 10R, ±1%, 1/20W, 0201, PbF; PartNumber: CR0201-20W-10R0FT; Designer: shyul; PCBMarker: Resistor; PinCountHole: 0; PinCountSMD: 2; Vendor: VENKEL; Component Kind: Standard; SerialNumber: 0; Value: 10 R; Version: 1.0; VersionDate: 04-27-2011; Library Name: Basc_1_Passive.IntLib; Publisher: Cowin
R21 R23 = RES: RES,SM,330OHM,1/20W,5%,THICK FILM,0201,H=.012IN; PartNumber: ERJ-1GEJ331C; Designer: shyul; PCBMarker: Resistor; PinCountHole: 0; PinCountSMD: 2; SerialNumber: 612236-01; Vendor: PANASONIC; Component Kind: Standard; Value: 330 R; Version: 1.0; VersionDate: 04-27-2011; Library Name: Basc_1_Passive.IntLib; Publisher: Cowin
R24 = RES: RES,SM,49.9,1/20W,1%,THICK FILM,0201,H=.012IN; PartNumber: CRCW020149R9FNED; Designer: shyul; PCBMarker: Resistor; PinCountHole: 0; PinCountSMD: 2; SerialNumber: 714206-01; Vendor: VISHAY-DALE; Component Kind: Standard; Value: 49.9 R; Version: 1.0; VersionDate: 04-27-2011; Library Name: Basc_1_Passive.IntLib; Publisher: Cowin
R25 R26 = RES: RES, 100R, ±5%, 1/20W, 0201, PbF; PartNumber: CR0201-20W-101JT; Designer: shyul; PCBMarker: Resistor; PinCountHole: 0; PinCountSMD: 2; Vendor: VENKEL; Component Kind: Standard; SerialNumber: 0; Value: 100 R; Version: 1.0; VersionDate: 04-27-2011; Library Name: Basc_1_Passive.IntLib; Publisher: Cowin
R29 = RES: RES, 30.9K, ±1%, 1/20W, 0201, PbF; PartNumber: CR0201-20W-3092FT; Designer: shyul; PCBMarker: Resistor; PinCountHole: 0; PinCountSMD: 2; Vendor: VENKEL; Component Kind: Standard; SerialNumber: 0; Value: 30.9 K; Version: 1.0; VersionDate: 04-27-2011; Library Name: Basc_1_Passive.IntLib; Publisher: Cowin
R30 R32 = RES: RES, SM, 1 OHM, 1/20W, 1%, THICK FILM, 0201, H=.011IN; PartNumber: RK73B1HTTC1R0J; Designer: shyul; PCBMarker: Resistor; PinCountHole: 0; PinCountSMD: 2; SerialNumber: 611161-01; Vendor: KOA; Component Kind: Standard; Value: 1 R; Version: 1.0; VersionDate: 04-27-2011; Library Name: Basc_1_Passive.IntLib; Publisher: Cowin
R31 = RES: RES, 10K, ±1%, 1/20W, 0201, PbF; PartNumber: CR0201-20W-1002FT; Designer: shyul; PCBMarker: Resistor; PinCountHole: 0; PinCountSMD: 2; Vendor: VENKEL; Component Kind: Standard; SerialNumber: 0; Value: 10 K; Version: 1.0; VersionDate: 04-27-2011; Library Name: Basc_1_Passive.IntLib; Publisher: Cowin
T1 T2 = TCM1-63AX+: TRANSFORMER,SM,TCM1-63AX+,RF,10MHZ-6000MHZ,H=.160IN; Designer: shyul; PCBMarker: TRANX; PinCountHole: 0; PinCountSMD: 6; SerialNumber: 723410-01; Vendor: Mini-Circuits; Component Kind: Standard; Library Name: IC_06_RF.IntLib; PartNumber: TCM1-63AX+; Value: TCM1-63AX+; Version: 1.0; VersionDate: 10-03-2013; Publisher: Cowin
U1 = AD9364: RF Agile Transceiver; PartNumber: AD9364BBCZ; Designer: shyul; PCBMarker: SDR; PinCountHole: 0; PinCountSMD: 144; Vendor: ADI; Component Kind: Standard; SerialNumber: 0; Value: AD9364; Version: 1.0; VersionDate: 07-17-2014; Library Name: Argon.SchLib; Publisher: Shyu Lee
U2 = SBB4089Z: 50MHz to 6000MHz High IP3 Amplifier; Designer: shyul; PCBMarker: GAIN; PinCountHole: 0; PinCountSMD: 4; Vendor: Mini-Circuits; Component Kind: Standard; Library Name: IC_06_RF.IntLib; PartNumber: PGA-102+; SerialNumber: 0; Value: PGA-102+; Version: 1.0; VersionDate: 04-07-2013; Publisher: Shyu Lee
U3 U4 = SKY13350-385LF: IC, SM, SKY13350-385LF, GAAS, SPDT, 0.01-6.0GHZ, 6-PIN MLPD, H = 0.02 IN; Designer: shyul; PCBMarker: SW; PinCountHole: 0; PinCountSMD: 6; SerialNumber: 697525-01; Vendor: Skyworks; Component Kind: Standard; Library Name: IC_06_RF.IntLib; PartNumber: SKY13350-385LF; Value: SKY13350-385LF; Version: 1.0; VersionDate: 04-03-2013; Publisher: Cowin
U5 = TPS7A8101: Low-Noise, Wide-Bandwidth, High PSRR, Low-Dropout 1-A Linear Regulator; PartNumber: TPS7A8101DRB; Designer: shyul; PCBMarker: Power; PinCountHole: 0; PinCountSMD: 8; Vendor: TI; Component Kind: Standard; SerialNumber: 0; Value: TPS7A8101; Version: 1.0; VersionDate: 11-11-2014; Library Name: Argon.SchLib; Publisher: Shyu Lee
U6 = ADP150: Ultralow Noise 150 mA CMOS Linear Regulator; PartNumber: ADP150ACBZ-1.8-R7; Designer: shyul; PCBMarker: Power; PinCountHole: 0; PinCountSMD: 4; Vendor: ADI; Component Kind: Standard; SerialNumber: 0; Value: ADP150-1.8; Version: 1.0; VersionDate: 01-07-2015; Library Name: Argon.SchLib; Publisher: Shyu Lee
